# Altium schematic sheet Panel.SchDoc
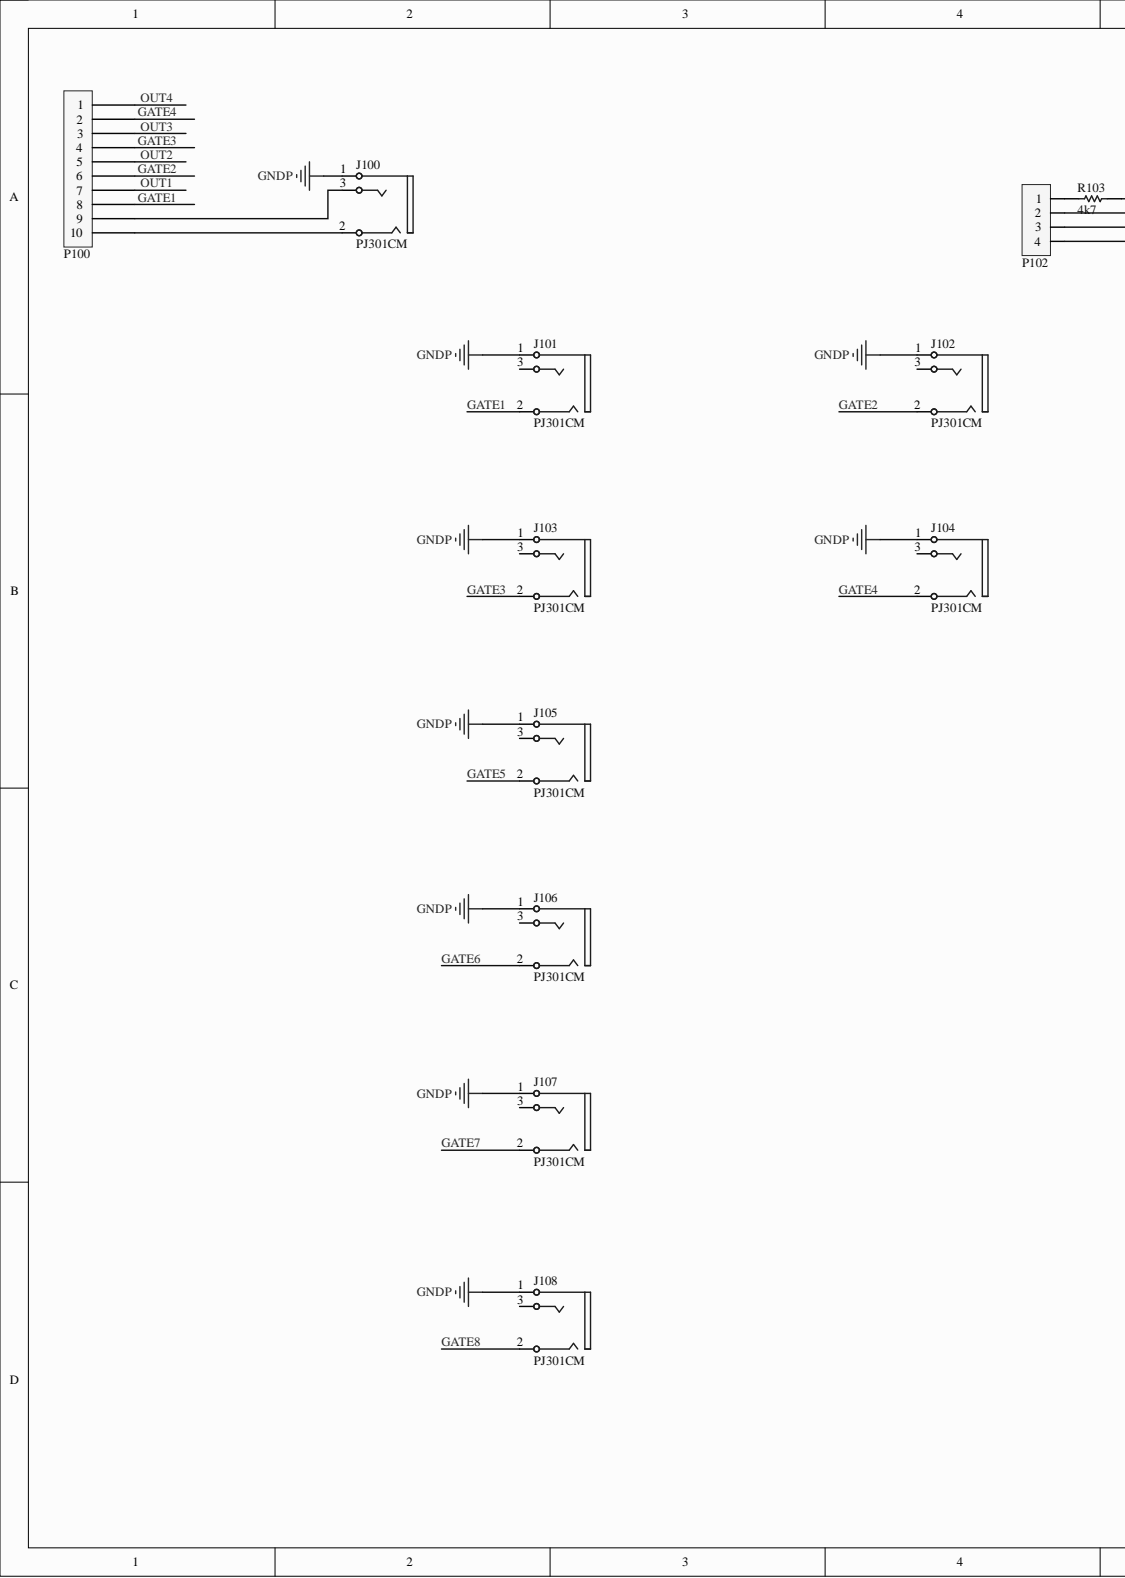
[diagram p0: Panel.SchDoc, left]
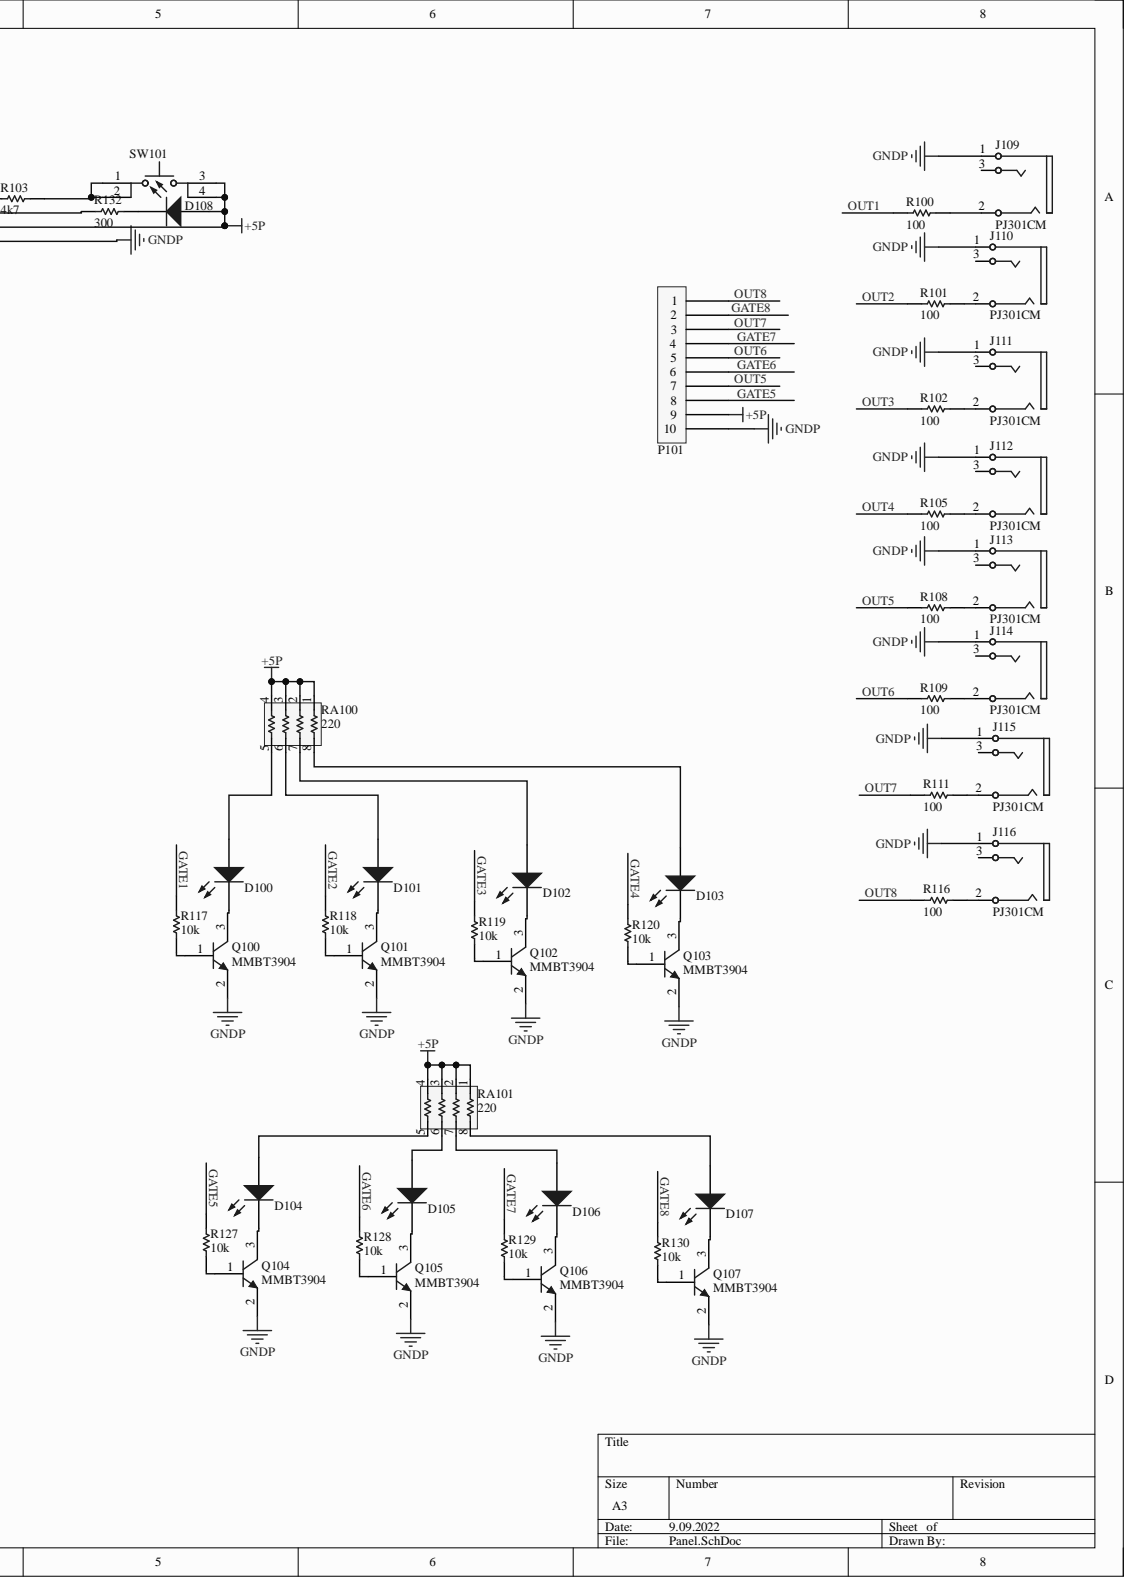
[diagram p1: Panel.SchDoc, right]

## Parts
D100  LED 3mm 90°  [PCB-13611427-1]  (value not drawn)
D101  LED 3mm 90°  [PCB-13611427-1]  (value not drawn)
D102  LED 3mm 90°  [PCB-13611427-1]  (value not drawn)
D103  LED 3mm 90°  [PCB-13611427-1]  (value not drawn)
D104  LED 3mm 90°  [PCB-13611427-1]  (value not drawn)
D105  LED 3mm 90°  [PCB-13611427-1]  (value not drawn)
D106  LED 3mm 90°  [PCB-13611427-1]  (value not drawn)
D107  LED 3mm 90°  [PCB-13611427-1]  (value not drawn)
D108  LED 3mm 90°  [PCB-13611427-1]  (value not drawn)
J100  PJ301CM  [PCB-l8b9lcrf9hhpa5wd71a5-1]
J101  PJ301CM  [PCB-l8b9lcrf9hhpa5wd71a5-1]
J102  PJ301CM  [PCB-l8b9lcrf9hhpa5wd71a5-1]
J103  PJ301CM  [PCB-l8b9lcrf9hhpa5wd71a5-1]
J104  PJ301CM  [PCB-l8b9lcrf9hhpa5wd71a5-1]
J105  PJ301CM  [PCB-l8b9lcrf9hhpa5wd71a5-1]
J106  PJ301CM  [PCB-l8b9lcrf9hhpa5wd71a5-1]
J107  PJ301CM  [PCB-l8b9lcrf9hhpa5wd71a5-1]
J108  PJ301CM  [PCB-l8b9lcrf9hhpa5wd71a5-1]
J109  PJ301CM  [PCB-l8b9lcrf9hhpa5wd71a5-1]
J110  PJ301CM  [PCB-l8b9lcrf9hhpa5wd71a5-1]
J111  PJ301CM  [PCB-l8b9lcrf9hhpa5wd71a5-1]
J112  PJ301CM  [PCB-l8b9lcrf9hhpa5wd71a5-1]
J113  PJ301CM  [PCB-l8b9lcrf9hhpa5wd71a5-1]
J114  PJ301CM  [PCB-l8b9lcrf9hhpa5wd71a5-1]
J115  PJ301CM  [PCB-l8b9lcrf9hhpa5wd71a5-1]
J116  PJ301CM  [PCB-l8b9lcrf9hhpa5wd71a5-1]
P100  TE Connectivity / AMP 1-87224-0  [PCB-cp1yv2tkluzxbj61re20-1]  (value not drawn)
P101  TE Connectivity / AMP 1-87224-0  [PCB-cp1yv2tkluzxbj61re20-1]  (value not drawn)
P102  FCI 68001-104HLF  [PCB-90ku6kdjhfi8i1gkzcxx-1]  (value not drawn)
Q100  MMBT3904  [ONSC-SOT-23-3-318-08_V]
Q101  MMBT3904  [ONSC-SOT-23-3-318-08_V]
Q102  MMBT3904  [ONSC-SOT-23-3-318-08_V]
Q103  MMBT3904  [ONSC-SOT-23-3-318-08_V]
Q104  MMBT3904  [ONSC-SOT-23-3-318-08_V]
Q105  MMBT3904  [ONSC-SOT-23-3-318-08_V]
Q106  MMBT3904  [ONSC-SOT-23-3-318-08_V]
Q107  MMBT3904  [ONSC-SOT-23-3-318-08_V]
R100  100  [RESC1609X55X30ML10T20]
R101  100  [RESC1609X55X30ML10T20]
R102  100  [RESC1609X55X30ML10T20]
R103  4k7  [RESC1609X55X30ML10T20]
R105  100  [RESC1609X55X30ML10T20]
R108  100  [RESC1609X55X30ML10T20]
R109  100  [RESC1609X55X30ML10T20]
R111  100  [RESC1609X55X30ML10T20]
R116  100  [RESC1609X55X30ML10T20]
R117  10k  [RESC1609X55X30ML10T20]
R118  10k  [RESC1609X55X30ML10T20]
R119  10k  [RESC1609X55X30ML10T20]
R120  10k  [RESC1609X55X30ML10T20]
R127  10k  [RESC1609X55X30ML10T20]
R128  10k  [RESC1609X55X30ML10T20]
R129  10k  [RESC1609X55X30ML10T20]
R130  10k  [RESC1609X55X30ML10T20]
R132  300  [RESC1609X55X30ML10T20]
RA100  220  [BOUR-CAY16-J4_V]
RA101  220  [BOUR-CAY16-J4_V]
SW101  E-Switch TL1105SPF160Q  [PCB-8yfsmi8mpilunsxpyoec-1]  (value not drawn)

## Nets
+5P: D108.2 P101.9 P102.3 RA100.1 RA100.2 RA100.3 RA100.4 RA101.1 RA101.2 RA101.3 RA101.4 SW101.3 SW101.4
GNDP: J100.1 J101.1 J102.1 J103.1 J104.1 J105.1 J106.1 J107.1 J108.1 J109.1 J110.1 J111.1 J112.1 J113.1 J114.1 J115.1 J116.1 P101.10 P102.4 Q100.2 Q101.2 Q102.2 Q103.2 Q104.2 Q105.2 Q106.2 Q107.2
GATE1: J101.2 P100.8 R117.2
GATE2: J102.2 P100.6 R118.2
GATE3: J103.2 P100.4 R119.2
GATE4: J104.2 P100.2 R120.2
GATE5: J105.2 P101.8 R127.2
GATE6: J106.2 P101.6 R128.2
GATE7: J107.2 P101.4 R129.2
GATE8: J108.2 P101.2 R130.2
OUT1: P100.7 R100.2
OUT2: P100.5 R101.2
OUT3: P100.3 R102.2
OUT4: P100.1 R105.2
OUT5: P101.7 R108.2
OUT6: P101.5 R109.2
OUT7: P101.3 R111.2
OUT8: P101.1 R116.2
NetD100_1: D100.1 Q100.3
NetD100_2: D100.2 RA100.5
NetD101_1: D101.1 Q101.3
NetD101_2: D101.2 RA100.6
NetD102_1: D102.1 Q102.3
NetD102_2: D102.2 RA100.7
NetD103_1: D103.1 Q103.3
NetD103_2: D103.2 RA100.8
NetD104_1: D104.1 Q104.3
NetD104_2: D104.2 RA101.5
NetD105_1: D105.1 Q105.3
NetD105_2: D105.2 RA101.6
NetD106_1: D106.1 Q106.3
NetD106_2: D106.2 RA101.7
NetD107_1: D107.1 Q107.3
NetD107_2: D107.2 RA101.8
NetD108_1: D108.1 R132.1
NetJ100_2: J100.2 P100.10
NetJ100_3: J100.3 P100.9
NetJ109_2: J109.2 R100.1
NetJ110_2: J110.2 R101.1
NetJ111_2: J111.2 R102.1
NetJ112_2: J112.2 R105.1
NetJ113_2: J113.2 R108.1
NetJ114_2: J114.2 R109.1
NetJ115_2: J115.2 R111.1
NetJ116_2: J116.2 R116.1
NetP102_1: P102.1 R103.2
NetP102_2: P102.2 R132.2
NetQ100_1: Q100.1 R117.1
NetQ101_1: Q101.1 R118.1
NetQ102_1: Q102.1 R119.1
NetQ103_1: Q103.1 R120.1
NetQ104_1: Q104.1 R127.1
NetQ105_1: Q105.1 R128.1
NetQ106_1: Q106.1 R129.1
NetQ107_1: Q107.1 R130.1
NetR103_1: R103.1 SW101.1 SW101.2

Not connected: J101 3; J102 3; J103 3; J104 3; J105 3; J106 3; J107 3; J108 3; J109 3; J110 3; J111 3; J112 3; J113 3; J114 3; J115 3; J116 3

## Library data of the parts
D100-D108 = CMP-96ad5dfef654496a-11: Color: Green; Component Kind: Standard; Description: LED 3mm 90°; Library Name: CommunityVault; OctopartID: 96ad5dfef654496a
J100-J116 = CMP-x7unslcrmd2amyyr5xzx-3: Stereo Audio Jack 3.5mm; Component Kind: Standard; Library Name: CommunityVault
P100 P101 = CMP-ea6c213c28e935ab-9: Connector Unshrouded Header 10 Position 2.54mm Solder Straight Thru-Hole; ELV Compliant: Compliant; Gender: Male; Lead-Free Status: Lead Free; Mounting Angle: 180 °; Number of Contacts: 10; Color: Black; Component Kind: Standard; Contact Material: Phosphor Bronze; Housing Color: Black; Library Name: CommunityVault; Mounting Style: PCB; Number of Positions: 10; Octopart URL: http://octopart.com/1-87224-0-te+connectivity+%2F+amp-39512035; OctopartID: ea6c213c28e935ab; Orientation: Vertical; RoHS: Compliant; Size-Height: 2.29 mm; Size-Length: 25.0 mm
P102 = CMP-d8b113d69cb60776-9: Conn; Rect; Header; Unshrouded; 4 Pos; 2.54mm Pitch; 1-Row; Thru-Hole; BergStik; Lead-Free Status: Lead Free; Component Kind: Standard; Library Name: CommunityVault; Mounting Style: Through Hole
Q100-Q107 = CMP-857791-2: General Purpose Transistor, NPN Silicon, 3-Pin SOT-23, Pb-Free, Tape and Reel; Breakdown Voltage [Collector to Emitter]: 60.0 V; Current Rating: 0.2 A; Lead-Free Status: Lead Free; Voltage Rating (DC): 40.0 V; Case/Package: SOT-23; Component Kind: Standard; Library Name: CommunityVault; Lifecycle Status: Active; Mounting Style: Surface Mount; Number of Pins: 3; Octopart Url: https://octopart.com/mmbt3904lt3g-on+semiconductor-357887; OctopartID: 10f4d9fb406dcf33; Polarity: NPN; RoHS: Compliant
R100-R103 R105 R108 R109 R111 R116-R120 R127-R130 R132 = CMP-1863112-2: RES SMD 1K OHM 0.1% 1/10W 0603; Lead-Free Status: Lead Free; Power Rating: 0.1 W; Resistance Tolerance: ±1%; Voltage Rating (DC): 75.0 V; Case/Package: 0603; Component Kind: Standard; Library Name: CommunityVault; Octopart Url: https://octopart.com/tnpw0603100kbeea-vishay-42335327; OctopartID: c79f0c101ea61858; REACH SVHC Compliance: No SVHC; RoHS: Compliant
  R100-R102 R105 R108 R109 R111 R116: Resistance: 100
  R103: Resistance: 4k7
  R117-R120 R127-R130: Resistance: 10k
  R132: Resistance: 300
RA100 RA101 = CMP-1150485-9: Chip Resistor Array, 8-Pin SMD 1206; Manufacturer Part Number: CAY16-103J4LF; Case/Package (SI): 3216; Lead-Free Status: Lead Free; Number of Circuits: 4; Number of Contacts: 8; Power Rating: 250 mW; Resistance Tolerance: ±5%; Voltage Rating (DC): 25.0 V; Case/Package: 1206; Component Kind: Standard; ComponentLink1Description: Manufacturer URL; ComponentLink1URL: http://www.bourns.com/; ComponentLink2Description: Datasheet; ComponentLink2URL: http://www.bourns.com/docs/Product-Datasheets/CATCAY.pdf; DatasheetVersion: Jan-15; Library Name: CommunityVault; Mounting Style: Surface Mount; Number of Pins: 8; Octopart Url: http://octopart.com/cay16-103j4lf-bourns-152968; OctopartID: c21e0b16b2f01dd9; PackageDescription: 8-Pin Surface Mount Device, Body 1.6 x 3.2 mm, Pitch 0.8 mm; PackageReference: CAY16-J4; Packaging: Cut Tape (CT); REACH SVHC Compliance: No SVHC; Resistance: 220; RoHS: Compliant; Seller Landing  Url: https://octopart.com/click/track?country=US&ak=8157f166&sig=0201b94&sid=1106&ppid=152968&vpid=14551898&ct=offers; Size-Height: 500 µm; Size-Length: 3.20 mm; Size-Width: 1.60 mm
SW101 = CMP-1f53d6491802c094-1: TL1105 Series SPST 1.6 N Round Button Right Angle Through Hole Tactile Switch; Contacts Type: SPST-NO; Lead-Free Status: Lead Free; Component Kind: Standard; Contact Plating: Silver; Library Name: CommunityVault; RoHS: Compliant
